# Altium schematic sheet MCU.SchDoc
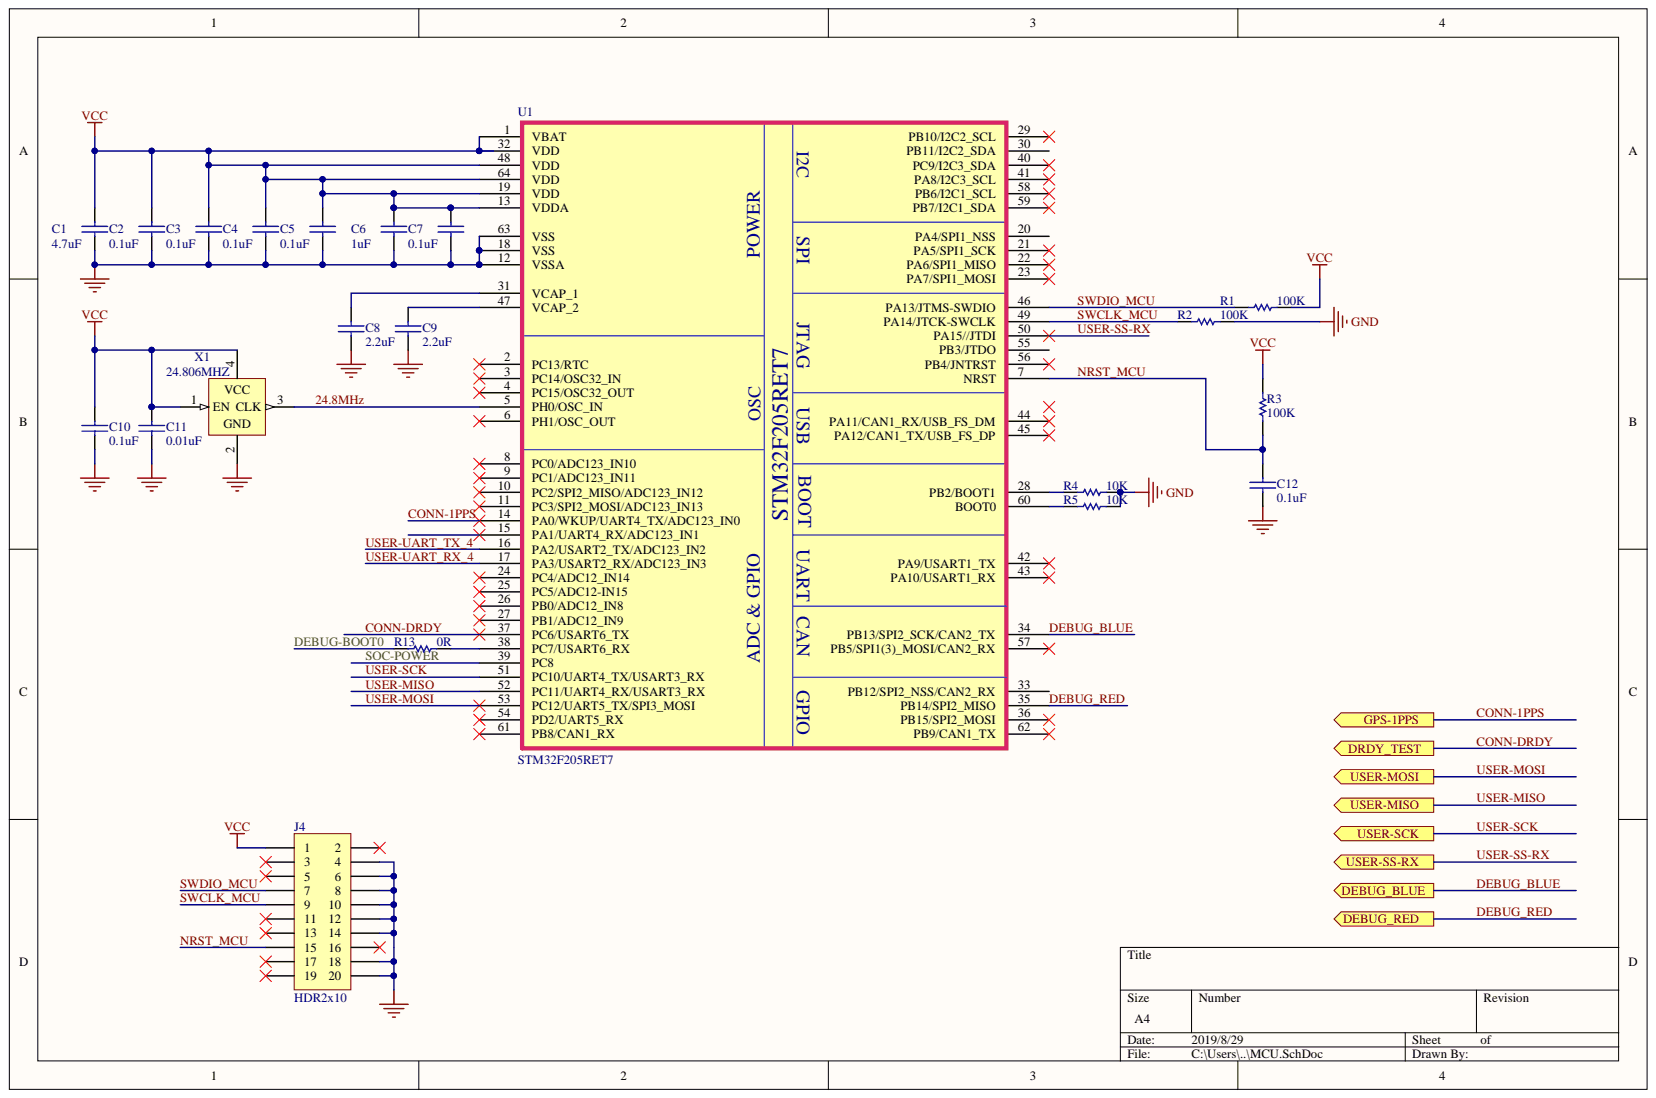
[diagram p0: MCU.SchDoc]

## Parts
C1  4.7uF  [LC-0603_C]
C2  0.1uF  [LC-0402_C]
C3  0.1uF  [LC-0402_C]
C4  0.1uF  [LC-0402_C]
C5  0.1uF  [LC-0402_C]
C6  1uF  [LC-0603_C]
C7  0.1uF  [LC-0402_C]
C8  2.2uF  [LC-0603_C]
C9  2.2uF  [LC-0603_C]
C10  0.1uF  [LC-0402_C]
C11  0.01uF  [LC-0402_C]
C12  0.1uF  [LC-0402_C]
J4  HDR2x10  [STLINK]
R1  100K  [LC-0402_R]
R2  100K  [LC-0402_R]
R3  100K  [LC-0402_R]
R4  10K  [LC-0402_R]
R5  10K  [LC-0402_R]
R13  0R  [LC-0402_R]
U1  STM32F205RET7  [IC-LQFP64]
X1  24.806MHZ  [OSC165P200X250X85-4N]

## Ports
DEBUG_BLUE, DEBUG_RED, DRDY_TEST, GPS-1PPS, USER-MISO, USER-MOSI, USER-SCK, USER-SS-RX

## Nets
GND: C1.2 C2.2 C3.2 C4.2 C5.2 C6.2 C7.2 C8.2 C9.2 C10.2 C11.2 C12.2 J4.4 J4.6 J4.8 J4.10 J4.12 J4.14 J4.18 J4.20 R2.1 R4.1 R5.1 U1.12(VSSA) U1.18(VSS) U1.63(VSS) X1.2
VCC: C1.1 C2.1 C3.1 C4.1 C5.1 C6.1 C7.1 C10.1 C11.1 J4.1 R1.1 R3.2 U1.1(VBAT) U1.13(VDDA) U1.19(VDD) U1.32(VDD) U1.48(VDD) U1.64(VDD) X1.1(EN) X1.4
24.8MHz: U1.5(PH0/OSC_IN) X1.3(CLK)
CONN-1PPS: U1.14(PA0/WKUP/UART4_TX/ADC123_IN0) ; port GPS-1PPS
CONN-DRDY: U1.37(PC6/USART6_TX) ; port DRDY_TEST
DEBUG-BOOT0: R13.2
DEBUG_BLUE: U1.34(PB13/SPI2_SCK/CAN2_TX) ; port DEBUG_BLUE
DEBUG_RED: U1.35(PB14/SPI2_MISO) ; port DEBUG_RED
NRST_MCU: C12.1 J4.15 R3.1 U1.7(NRST)
SOC-POWER: U1.39(PC8)
SWCLK_MCU: J4.9 R2.2 U1.49(PA14/JTCK-SWCLK)
SWDIO_MCU: J4.7 R1.2 U1.46(PA13/JTMS-SWDIO)
USER-MISO: U1.52(PC11/UART4_RX/USART3_RX) ; port USER-MISO
USER-MOSI: U1.53(PC12/UART5_TX/SPI3_MOSI) ; port USER-MOSI
USER-SCK: U1.51(PC10/UART4_TX/USART3_RX) ; port USER-SCK
USER-SS-RX: U1.50(PA15//JTDI) ; port USER-SS-RX
USER-UART_RX_4: U1.17(PA3/USART2_RX/ADC123_IN3)
USER-UART_TX_4: U1.16(PA2/USART2_TX/ADC123_IN2)
NetC8_1: C8.1 U1.31(VCAP_1)
NetC9_1: C9.1 U1.47(VCAP_2)
NetR4_2: R4.2 U1.28(PB2/BOOT1)
NetR5_2: R5.2 U1.60(BOOT0)
NetR13_1: R13.1 U1.38(PC7/USART6_RX)

Not connected: J4 2 3 5 11 13 16 17 19; U1 2(PC13/RTC) 3(PC14/OSC32_IN) 4(PC15/OSC32_OUT) 6(PH1/OSC_OUT) 8(PC0/ADC123_IN10) 9(PC1/ADC123_IN11) 10(PC2/SPI2_MISO/ADC123_IN12) 11(PC3/SPI2_MOSI/ADC123_IN13) 15(PA1/UART4_RX/ADC123_IN1) 20(PA4/SPI1_NSS) 21(PA5/SPI1_SCK) 22(PA6/SPI1_MISO) 23(PA7/SPI1_MOSI) 24(PC4/ADC12_IN14) 25(PC5/ADC12-IN15) 26(PB0/ADC12_IN8) 27(PB1/ADC12_IN9) 29(PB10/I2C2_SCL) 30(PB11/I2C2_SDA) 33(PB12/SPI2_NSS/CAN2_RX) 36(PB15/SPI2_MOSI) 40(PC9/I2C3_SDA) 41(PA8/I2C3_SCL) 42(PA9/USART1_TX) 43(PA10/USART1_RX) 44(PA11/CAN1_RX/USB_FS_DM) 45(PA12/CAN1_TX/USB_FS_DP) 54(PD2/UART5_RX) 55(PB3/JTDO) 56(PB4/JNTRST) 57(PB5/SPI1(3)_MOSI/CAN2_RX) 58(PB6/I2C1_SCL) 59(PB7/I2C1_SDA) 61(PB8/CAN1_RX) 62(PB9/CAN1_TX)

## Library data of the parts
C1 = CAP SMD 0402_4: SCAP, CER, 4.7uF, 6.3V, 10%, X5R, 0402, -55℃~85℃, RoHS; PRIMARY MANUFACTURER: TDK; PRIMARY MPN: C1005X5R0J475K050BC; Component Kind: Standard; Library Name: 电容MLCC.SchLib; PRICE: 0.002$@1K
C2-C5 C7 C10 C12 = CAP SMD 0402_3: SCAP, CER, 0.1uF, 25V, 10%, X7R, 0402, -55℃~125℃, RoHS; PRIMARY MANUFACTURER: TDK; PRIMARY MPN: C1005X7R1E104K050BB; Component Kind: Standard; Library Name: 电容MLCC.SchLib; PRICE: 0.002$@1K
C6 = CAP SMD 0402_2: SCAP, CER, 1uF, 6.3V, 10%, X5R, 0402, -55℃~85℃, RoHS; PRIMARY MANUFACTURER: TDK; PRIMARY MPN: C1005X5R1C105K; Component Kind: Standard; Library Name: 电容MLCC.SchLib; PRICE: 0.002$@1K
C8 C9 = CAP SMD 0402_1: SCAP, CER, 2.2uF, 10V, 20%, X5R, 0402, -55℃~85℃, RoHS; PRIMARY MANUFACTURER: KEMET; PRIMARY MPN: C0402C225M8PACTU; Component Kind: Standard; Library Name: 电容MLCC.SchLib
C11 = CAP SMD 0402_5: SCAP, CER, 0.01uF, 25V, 10%, X7R, 0402, -55℃~125℃, RoHS; PRIMARY MANUFACTURER: TDK; PRIMARY MPN: C1005X7R1E103K050BB; Component Kind: Standard; Library Name: 电容MLCC.SchLib; PRICE: 0.002$@1K
J4 = HDR2x10: Connector; Component Kind: Standard; Library Name: General.SchLib
R1-R3 = RES1_3: RES,100k OHM,1/10W,5%,0603; PRIMARY MANUFACTURER: PANASONIC; PRIMARY MPN: ERJ-3GEY0R00V; PN: 4040-0003-01; Component Kind: Standard; Library Name: 电阻.SchLib
R4 R5 R13 = RES1_2: RES,10k OHM,1/10W,5%,0603; PRIMARY MANUFACTURER: PANASONIC; PRIMARY MPN: ERJ-3GEY0R00V; PN: 4040-0003-01; Component Kind: Standard; Library Name: 电阻.SchLib
U1 = STM32F205RET7: IC, ARM, 32BIT, 512KB FLASH, LQFP64, -40°C ~ 105°C, RoHS; PRIMARY MANUFACTURER: ST; Component Kind: Standard; PRICE: 3$@1K; PRIMARY MPN: STM32F205RET7; Library Name: MEMSIC.SchLib
X1 = 24.806MHZ: PRIMARY MANUFACTURER: SiTime; PRIMARY MPN: SiT8008BIL11-33S-24.806000; Component Kind: Standard; Description: 24.806MHZ; Library Name: MTLT(CAN)-Dexter-201708.SCHLIB
